# Altium schematic sheet Airspeed2_B2.SchDoc
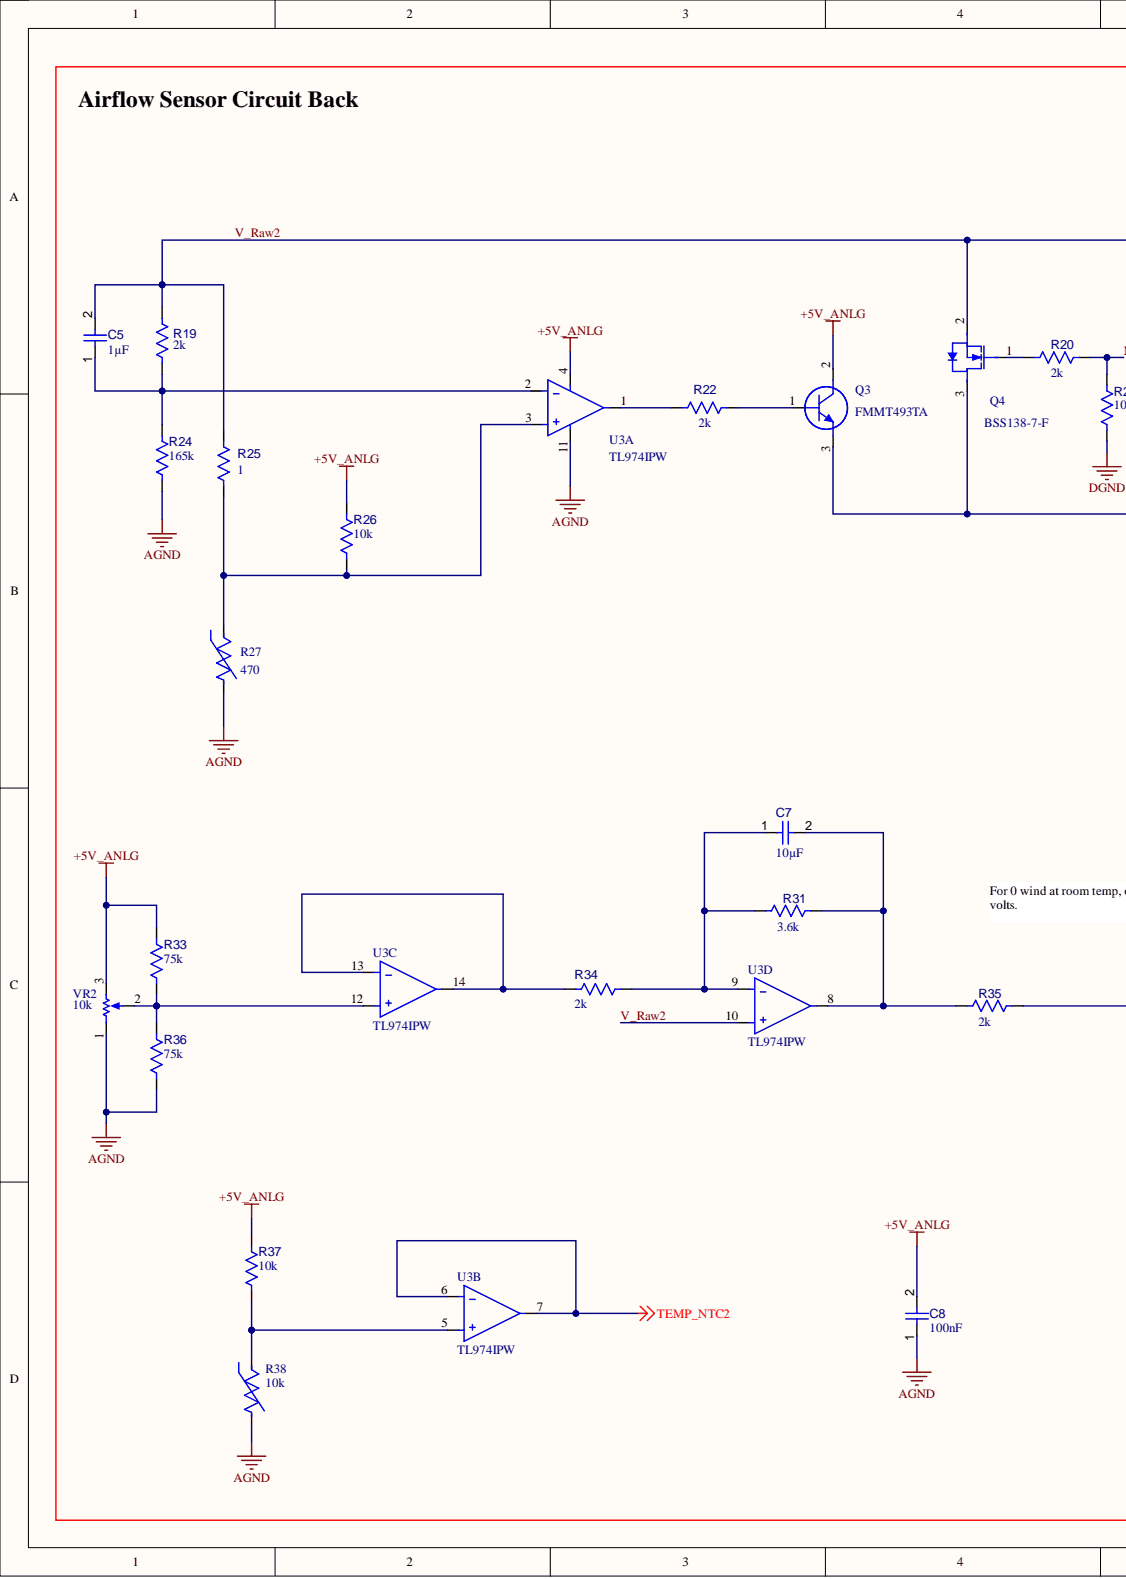
[diagram p0: Airspeed2_B2.SchDoc, left]
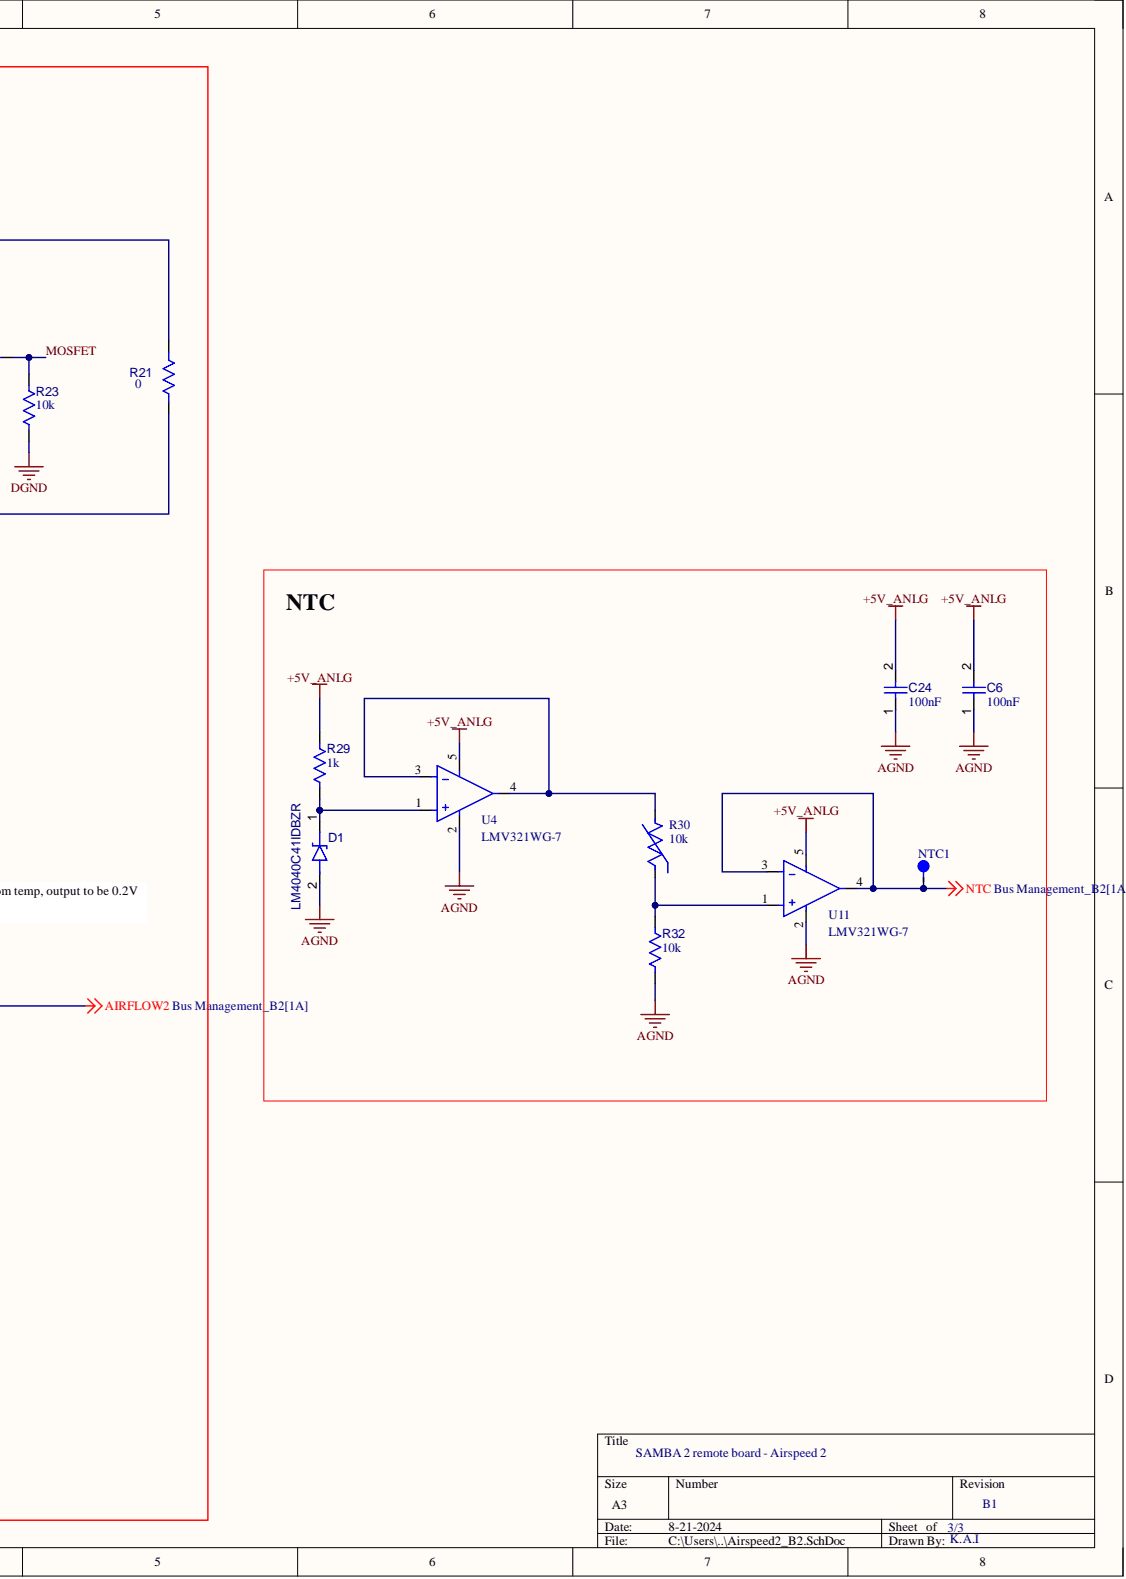
[diagram p1: Airspeed2_B2.SchDoc, right]

## Parts
C5  value 1µF  [FP-CL10-IPC_C]  (hidden comment: CL10B105KA8NFNC)
C6  value 100nF  [FP-CC0603-DA-MFG]  (hidden comment: CC0603KRX7R7BB104)
C7  value 10µF  [FP-GRM188-0_15-MFG]  (hidden comment: GRM188R61A106ME69D)
C8  value 100nF  [FP-CC0603-DA-MFG]  (hidden comment: CC0603KRX7R7BB104)
C24  value 100nF  [FP-CC0603-DA-MFG]  (hidden comment: CC0603KRX7R7BB104)
D1  LM4040C41IDBZR  [DBZ0003A_M]
NTC1  PH1-01-UA  [FP-PH1-01-UA-MFG]  (value not drawn)
Q3  FMMT493TA  [SOT95P237X110-3N]
Q4  BSS138-7-F  [DIOD-SOT23-3_V]
R19  value 2k  [IPC B 0603 RES]  (hidden comment: ERJ-3EKF2001V)
R20  value 2k  [IPC B 0603 RES]  (hidden comment: ERJ-3EKF2001V)
R21  value 0  [FP-RMCF0603-MFG]  (hidden comment: Resistor 0R +/- 5% 0603 100 mW)
R22  value 2k  [IPC B 0603 RES]  (hidden comment: ERJ-3EKF2001V)
R23  value 10k  [FP-RC0603-0_55-MFG]  (hidden comment: RC0603FR-0710KL)
R24  value 165k  [RESC1608X55X30NL15T15]  (hidden comment: ERJ-3EKF1653V)
R25  value 1  [Vishay_CRCW0805-HPe3_MFG]  (hidden comment: CRCW08051R00FKEAHP)
R26  value 10k  [FP-RC0603-0_55-MFG]  (hidden comment: RC0603FR-0710KL)
R27  value 470  [NTCG103NH471JT1-Footprint-1]  (hidden comment: NTCG103NH471JT1)
R29  value 1k  [RESC1608X55X25ML10T15]  (hidden comment: Resistor 1k +/-1% 0603 100 mW)
R30  value 10k  [TTS-10KC3-BG-Footprint-1]  (hidden comment: TTS-10KC3-BG)
R31  value 3.6k  [RESC1609X50X30NL08T20]  (hidden comment: CRCW06033K60FKEAC)
R32  value 10k  [FP-RC0603-0_55-MFG]  (hidden comment: RC0603FR-0710KL)
R33  value 75k  [IPC B 0603 RES]  (hidden comment: AC0603FR-0775KL)
R34  value 2k  [IPC B 0603 RES]  (hidden comment: ERJ-3EKF2001V)
R35  value 2k  [IPC B 0603 RES]  (hidden comment: ERJ-3EKF2001V)
R36  value 75k  [IPC B 0603 RES]  (hidden comment: AC0603FR-0775KL)
R37  value 10k  [FP-RC0603-0_55-MFG]  (hidden comment: RC0603FR-0710KL)
R38  value 10k  [FP-NCP15-NCU15-0_05-MFG]  (hidden comment: NCP15XH103J03RC)
U3  TL974IPW  [TSOP65P640X120-14N]  (parts A,B,C,D)
U4  LMV321WG-7  [SOT95P285X130-5N]
U11  LMV321WG-7  [SOT95P285X130-5N]
VR2  value 10k  [22AR10KLFTR-Footprint-1]  (hidden comment: 22AR10KLFTR)

## Nets
+5V_ANLG: C6.2 C8.2 C24.2 Q3.2 R26.2 R29.2 R33.2 R37.2 U3.4 U4.5 U11.5 VR2.3
AGND: C6.1 C8.1 C24.1 D1.2 R24.1 R27.2 R32.1 R36.1 R38.2 U3.11 U4.2 U11.2 VR2.1
AIRFLOW2: R35.1
DGND: R23.1
NTC: NTC1.1 U11.3 U11.4
TEMP_NTC2: U3.6 U3.7
MOSFET: R20.1 R23.2
V_Raw2: C5.2 Q4.2 R19.2 R21.2 R25.2 U3.10
NetC5_1: C5.1 R19.1 R24.2 U3.2
NetC7_1: C7.1 R31.1 R34.1 U3.9
NetC7_2: C7.2 R31.2 R35.2 U3.8
NetD1_1: D1.1 R29.1 U4.1
NetQ3_1: Q3.1 R22.1
NetQ3_3: Q3.3 Q4.3 R21.1
NetQ4_1: Q4.1 R20.2
NetR22_2: R22.2 U3.1
NetR25_1: R25.1 R26.1 R27.1 U3.3
NetR30_1: R30.1 R32.2 U11.1
NetR30_2: R30.2 U4.3 U4.4
NetR33_1: R33.1 R36.2 U3.12 VR2.2
NetR34_2: R34.2 U3.13 U3.14
NetR37_1: R37.1 R38.1 U3.5

## Library data of the parts
C5 = CMP-001-00098-1: Ceramic Capacitor, Multilayer, Ceramic, 25V, 10% +Tol, 10% -Tol, X7R, 15% TC, 1uF, Surface Mount, 0603; Capacitor Type: Ceramic; Case/Package: 0603; Component Kind: Standard; Max Operating Temperature: 125°C; Min Operating Temperature: -55°C; Mounting Technology: Surface Mount; Pins: 2; RoHS Compliant: Yes; Library Name: University of Sydney
C6 C8 C24 = CMP-001-00099-1: Ceramic Capacitor, Multilayer, Ceramic, 16V, 10% +Tol, 10% -Tol, X7R, 15% TC, 0.1uF, Surface Mount, 0603; Capacitor Type: Ceramic; Case/Package: 0603; Component Kind: Standard; Max Operating Temperature: 125°C; Min Operating Temperature: -55°C; Mounting Technology: Surface Mount; Pins: 2; RoHS Compliant: Yes; Library Name: University of Sydney
C7 = CMP-001-00097-1: Ceramic Capacitor, Multilayer, Ceramic, 10V, 20% +Tol, 20% -Tol, X5R, 15% TC, 10uF, Surface Mount, 0603; Capacitor Type: Ceramic; Case/Package: 0603; Component Kind: Standard; Max Operating Temperature: 85°C; Min Operating Temperature: -55°C; Mounting Technology: Surface Mount; Pins: 2; RoHS Compliant: Yes; Library Name: University of Sydney
D1 = CMP-004-00033-2: Two Terminal Voltage Reference, 1 Output, 4.096V, BIPolar, PDSO3; Case/Package: TSSOP; Component Kind: Standard; Max Operating Temperature: 85°C; Min Operating Temperature: -40°C; Pins: 3; RoHS Compliant: Yes; Library Name: University of Sydney
NTC1 = CMP-002-00083-1: Conn Unshrouded Header HDR 1 POS 2.54mm Solder ST Thru-Hole Bag; Component Kind: Standard; Library Name: University of Sydney
Q3 = CMP-013-00034-1: Small Signal Bipolar Transistor, 1A I(C), 100V V(BR)CEO, 1-Element, NPN, Silicon; Frequency: 150MHz; Component Kind: Standard; Max Operating Temperature: 150°C; Pins: 3; RoHS Compliant: Yes; Library Name: University of Sydney
Q4 = CMP-00001-1: Small Signal Field-Effect Transistor, 0.2A I(D), 50V, 1-Element, N-Channel, Silicon, Metal-oxide Semiconductor FET; Drain Current-Max (Abs) (ID): 200mA; Drain-source On Resistance-Max: 3.5R; Feedback Cap-Max (Crss): 8pF; Number of Elements: 1; Operating Mode: ENHANCEMENT MODE; Package Body Material: Plastic; Power Dissipation-Max (Abs): 300mW; Qualification Status: Not Qualified; Time @ Peak Reflow Temperature-Max (s): 40s; Transistor Application: SWITCHING; Component Kind: Standard; Drain Current-Max (ID): 200mA; DS Breakdown Voltage-Min: 50V; FET Technology: METAL-OXIDE SEMICONDUCTOR; JESD-30 Code: R-PDSO-G3; JESD-609 Code: e3; Max Operating Temperature: 150°C; Moisture Sensitivity Level: 1; Package Shape: Rectangular; Package Style: SMALL OUTLINEMeter; Peak Reflow Temperature (Cel): 260°C; Pins: 3; Polarity/Channel Type: N-CHANNEL; Reach SVHC Compliant: Yes; RoHS Compliant: Yes; Surface Mount: Yes; Terminal Finish: Tin; Terminal Form: Gull Wing; Terminal Position: DUAL; Transistor Element Material: SILICON; Library Name: University of Sydney
R19 R20 R22 R34 R35 = CMP-009-00207-1: Fixed Resistor, Metal Glaze/thick Film, 0.1W, 2000ohm, 75V, 1% +/-Tol, 100ppm/Cel, Surface Mount, 0603; Power: 100mW; Case/Package: 0603; Component Kind: Standard; Max Operating Temperature: 155°C; Min Operating Temperature: -55°C; Mounting Technology: Surface Mount; Pins: 2; RoHS Compliant: Yes; Tolerance: 1%; Library Name: University of Sydney
R21 = CMP-009-00006-7: Chip Resistor, 0 Ohm, 0.1 W, -55 to 155 degC, 0603 (1608 Metric); Power: 100mW; Voltage Rating: 50V; Case/Package: 0603; Component Kind: Standard; Max Operating Temperature: 155°C; Min Operating Temperature: -55°C; Mounting Technology: SM; Pins: 2; RoHS Compliant: True; Tolerance: 5%; Library Name: University of Sydney
R23 R26 R32 R37 = CMP-009-00204-1: Fixed Resistor, Metal Glaze/thick Film, 0.1W, 10000ohm, 75V, 1% +/-Tol, 100ppm/Cel, Surface Mount, 0603; Power: 100mW; Case/Package: 0603; Component Kind: Standard; Max Operating Temperature: 155°C; Min Operating Temperature: -55°C; Mounting Technology: Surface Mount; Pins: 2; RoHS Compliant: Yes; Tolerance: 1%; Library Name: University of Sydney
R24 = CMP-009-00209-1: Fixed Resistor, Metal Glaze/thick Film, 0.1W, 165000ohm, 75V, 1% +/-Tol, 100ppm/Cel, Surface Mount, 0603; Power: 100mW; Case/Package: 0603; Component Kind: Standard; Max Operating Temperature: 155°C; Min Operating Temperature: -55°C; Mounting Technology: Surface Mount; Pins: 2; RoHS Compliant: Yes; Tolerance: 1%; Library Name: University of Sydney
R25 = CMP-009-00205-1: Fixed Resistor, Metal Glaze/thick Film, 0.5W, 1ohm, 150V, 1% +/-Tol, 100ppm/Cel, Surface Mount, 0805; Power: 500mW; Case/Package: 0805; Component Kind: Standard; Max Operating Temperature: 155°C; Min Operating Temperature: -55°C; Mounting Technology: Surface Mount; Pins: 2; RoHS Compliant: Yes; Tolerance: 1%; Library Name: University of Sydney
R27 = CMP-009-00192-3: NTC Thermistor, 470ohm, Surface Mount; Power: 100mW; Tolerance: 5%; Component Kind: Standard; Max Operating Temperature: 125°C; Min Operating Temperature: -40°C; Mounting Technology: Surface Mount; Pins: 2; RoHS Compliant: Yes; Library Name: University of Sydney
R29 = CMP-009-00014-6: Chip Resistor, 1 KOhm, +/-1%, 0.1 W, -55 to 155 degC, 0603 (1608 Metric); Power: 100mW; Tolerance: 1%; Voltage Rating: 50V; Case/Package: 0603; Component Kind: Standard; Max Operating Temperature: 155°C; Min Operating Temperature: -55°C; Mounting Technology: SM; Pins: 2; RoHS Compliant: True; Library Name: University of Sydney
R30 = CMP-009-00201-1: Thermistor Ntc 10 Kohm 3977K Bead; Component Kind: Standard; Library Name: University of Sydney
R31 = CMP-009-00200-1: Fixed Resistor, Metal Glaze/thick Film, 0.1W, 3600ohm, 75V, 1% +/-Tol, 100ppm/Cel, Surface Mount, 0603; Power: 100mW; Case/Package: 0603; Component Kind: Standard; Max Operating Temperature: 155°C; Min Operating Temperature: -55°C; Pins: 2; RoHS Compliant: Yes; Tolerance: 1%; Library Name: University of Sydney
R33 R36 = CMP-009-00208-1: Fixed Resistor, Metal Glaze/thick Film, 0.1W, 75000ohm, 75V, 1% +/-Tol, 100ppm/Cel, Surface Mount, 0603; Power: 100mW; Case/Package: 0603; Component Kind: Standard; Max Operating Temperature: 155°C; Min Operating Temperature: -55°C; Mounting Technology: Surface Mount; Pins: 2; RoHS Compliant: Yes; Tolerance: 1%; Library Name: University of Sydney
R38 = CMP-009-00202-2: NTC Thermistor, 10000ohm, Surface Mount; Power: 100mW; Tolerance: 5%; Case/Package: 0402; Component Kind: Standard; Max Operating Temperature: 125°C; Min Operating Temperature: -40°C; Mounting Technology: Surface Mount; Pins: 2; RoHS Compliant: Yes; Library Name: University of Sydney
U3 = CMP-014-00004-4: Operational Amplifier, 4 Func, 6000uV Offset-Max, BIPolar, PDSO14; Channels: 4; Nominal Supply Voltage: 2.5V; Case/Package: TSSOP; Component Kind: Standard; Max Operating Temperature: 125°C; Min Operating Temperature: -40°C; Pins: 14; RoHS Compliant: Yes; Library Name: University of Sydney
U4 U11 = CMP-014-00005-1: Operational Amplifier, 1 Func, 9000uV Offset-Max, CMOS, PDSO5; Channels: 1; Nominal Supply Voltage: 2.7V; Case/Package: LSSOP; Component Kind: Standard; Max Operating Temperature: 85°C; Min Operating Temperature: -40°C; Pins: 5; RoHS Compliant: Yes; Library Name: University of Sydney
VR2 = CMP-009-00203-1: Trimmer, Cermet, 0.125W, 10000ohm, 200V, 20% +/-Tol, 100ppm/Cel, 1 Turn(s), 1413; Power: 125mW; Case/Package: 1214; Component Kind: Standard; Max Operating Temperature: 125°C; Min Operating Temperature: -55°C; Mounting Technology: Surface Mount; Pins: 3; RoHS Compliant: Yes; Tolerance: 20%; Library Name: University of Sydney
